# Altium schematic sheet Power.SchDoc
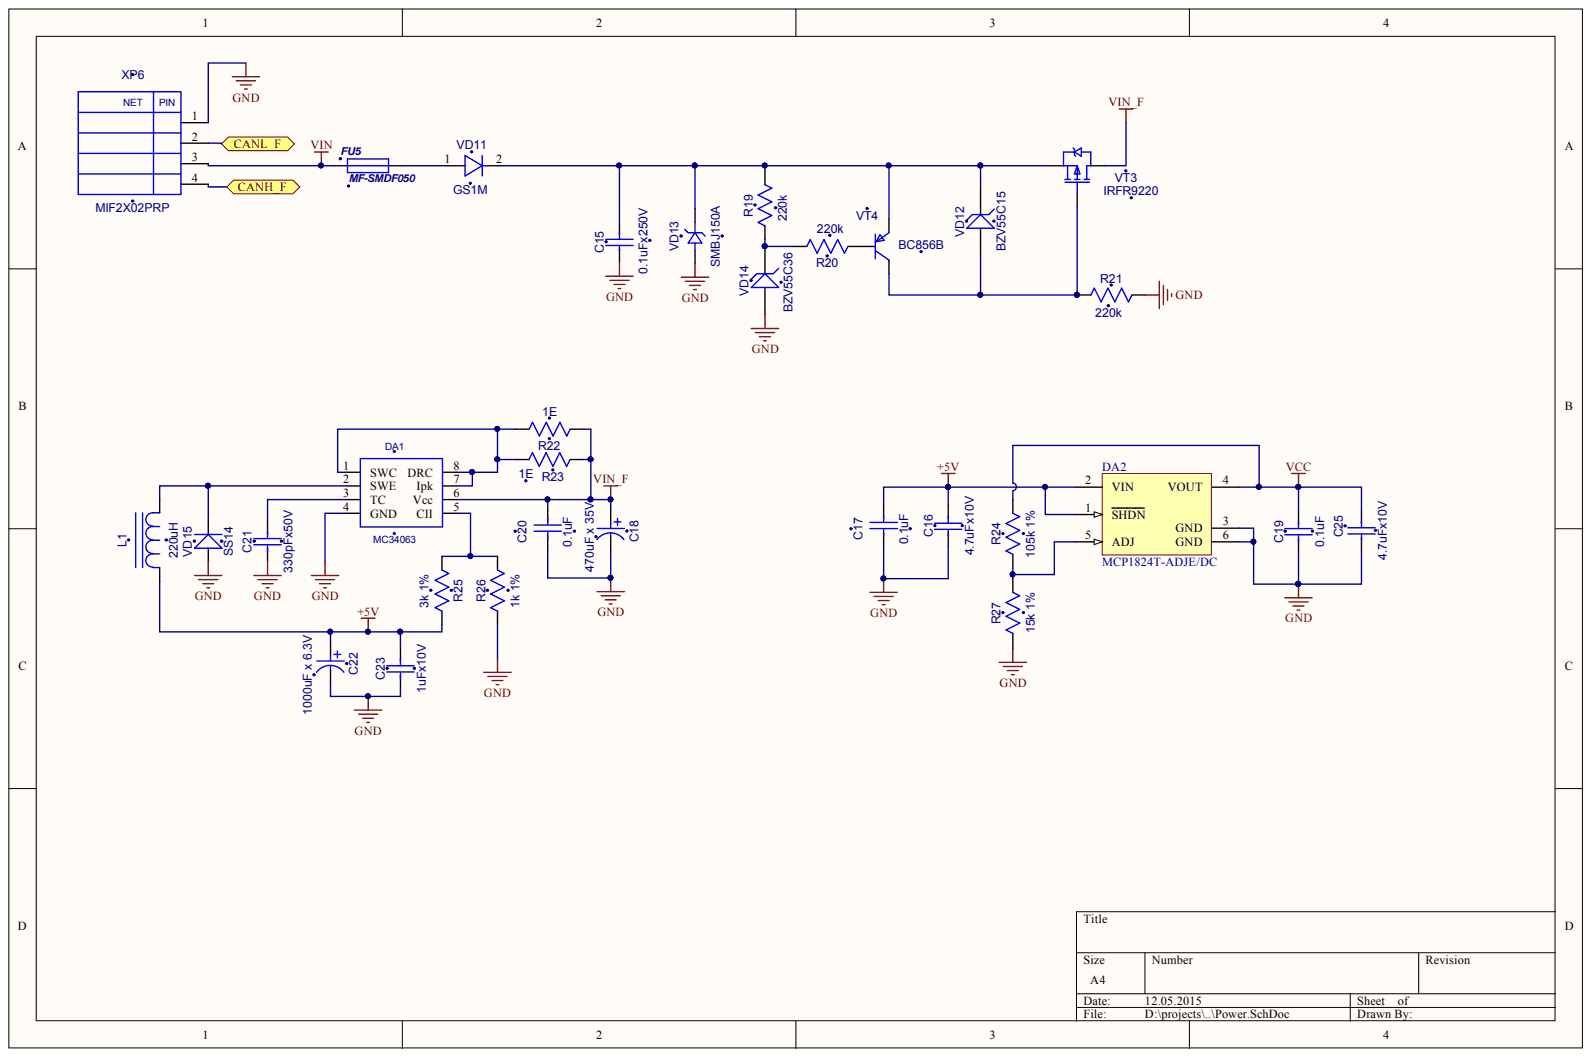
[diagram p0: Power.SchDoc]

## Parts
C15  0.1uFx250V  [CC1206-MIDI]
C16  4.7uFx10V  [CC0805-MIDI-2M1]
C17  0.1uF  [CC0603-3M]
C18  470uF x 35V  [CC16X10_CAP0.5(1)(Primary)]
C19  0.1uF  [CC0603-3M]
C20  0.1uF  [CC0603-3M]
C21  330pFx50V  [CC0603-3M]
C22  1000uF x 6.3V  [CC8X3.5]
C23  1uFx10V  [CC0603-3M]
C25  4.7uFx10V  [CC0805-MIDI-2M1]
DA1  MC34063  [SO-8]
DA2  MCP1824T-ADJE/DC  [SOT223-6]
FU5  MF-SMDF050  [MF-SMDF]
L1  220uH  [SDR0805]
R19  220k  [RC1206-2M]
R20  220k  [RC1206-2M]
R21  220k  [RC1206-2M]
R22  1E  [RC0805_IPC_NOM]
R23  1E  [RC0805_IPC_NOM]
R24  105k 1%  [RC0603-3M]
R25  3k 1%  [RC0603-3M]
R26  1k 1%  [RC0603-3M]
R27  15k 1%  [RC0603-3M]
VD11  GS1M  [SMA]
VD12  BZV55C15  [SOD-80]
VD13  SMBJ150A  [SMB]
VD14  BZV55C36  [SOD-80]
VD15  SS14  [SMA]
VT3  IRFR9220  [D-PAK(TO-252AA)]
VT4  BC856B  [SOT-23(123)]
XP6  MIF2X02PRP  [MIF2X02PRP]

## Ports
CANH_F, CANL_F

## Nets
+5V: C16.2 C17.1 C22.1 C23.2 DA2.1(SHDN) DA2.2(VIN) L1.1 R25.2
GND: C15.1 C16.1 C17.2 C18.1 C19.1 C20.2 C21.1 C22.2 C23.1 C25.1 DA1.4(GND) DA2.3(GND) DA2.6(GND) R21.2 R26.1 R27.1 VD13.1 VD14.1 VD15.1 XP6.1
VCC: C19.2 C25.2 DA2.4(VOUT) R24.2
VIN: FU5.1 XP6.3
VIN_F: C18.2 C20.1 DA1.6(Vcc) R22.1 R23.1 VT3.3
CANH_F: XP6.4 ; port CANH_F
CANL_F: XP6.2 ; port CANL_F
NetC15_2: C15.2 R19.2 VD11.2 VD12.2 VD13.2 VT3.2 VT4.2
NetC21_2: C21.2 DA1.3(TC)
NetDA1_1: DA1.1(SWC) DA1.7(Ipk) DA1.8(DRC) R22.2 R23.2
NetDA1_2: DA1.2(SWE) L1.2 VD15.2
NetDA1_5: DA1.5(CII) R25.1 R26.2
NetDA2_5: DA2.5(ADJ) R24.1 R27.2
NetFU5_2: FU5.2 VD11.1
NetR19_1: R19.1 R20.2 VD14.2
NetR20_1: R20.1 VT4.1
NetR21_1: R21.1 VD12.1 VT3.1 VT4.3

## Library data of the parts
C15 = CC1206: Component Kind: Standard; Description: CC1206; Value: 0.1uFx250V; Library Name: gprs_modem.SchLib
C16 C25 = CC0805: Component Kind: Standard; Description: CC0805; Value: 4.7uFx10V; Library Name: gprs_modem.SchLib
C17 C19-C21 C23 = CC0603: Component Kind: Standard; Description: CC0603; Library Name: gprs_modem.SchLib
  C17 C19 C20: Value: 0.1uF
  C21: Value: 330pFx50V
  C23: Value: 1uFx10V
C18 = CAP0.5(1): Component Kind: Standard; Description: CAP0.5(1); Value: 470uF x 35V; Library Name: gprs_modem.SchLib
C22 = CAP0.35: Component Kind: Standard; Description: CAP0.35; Value: 1000uF x 6.3V; Library Name: gprs_modem.SchLib
DA2 = MCP1824T-ADJE/DC: 300 mA, Low Voltage, Low Quiescent Current LDO Regulator, 5-Pin SOT-223, Extended Temperature, Tape and Reel; Component Kind: Standard; ComponentLink1Description: Manufacturer Link; ComponentLink1URL: http://www.microchip.com; ComponentLink2Description: Datasheet; ComponentLink2URL: http://ww1.microchip.com/downloads/en/DeviceDoc/22070a.pdf; ComponentLink3Description: Packaging Specification; ComponentLink3URL: http://ww1.microchip.com/downloads/en/PackagingSpec/00049AW.pdf; DatasheetVersion: revA, Aug-2007; PackageDescription: 5-Lead Plastic Small Outline Transistor (DC) [SOT-223]; PackageReference: SOT-223-DC5; PackageVersion: revAW, Oct-2008; Published: 29-Jan-2009; Library Name: gprs_modem.SchLib; Publisher: Altium Limited
FU5 = MF-SMDF: Component Kind: Standard; Description: MF-SMDF; Value: MF-SMDF050; Library Name: gprs_modem.SchLib
L1 = SDR0805: Component Kind: Standard; Description: SDR0805; Value: 220uH; Library Name: gprs_modem.SchLib
R19-R21 = RC1206: Component Kind: Standard; Description: RC1206; Value: 220k; Library Name: gprs_modem.SchLib
R22 R23 = RC0805: Component Kind: Standard; Description: RC0805; Value: 1E; Library Name: gprs_modem.SchLib
R24-R27 = RC0603: Component Kind: Standard; Description: RC0603; Library Name: gprs_modem.SchLib
  R24: Value: 105k 1%
  R25: Value: 3k 1%
  R26: Value: 1k 1%
  R27: Value: 15k 1%
VD11 = GS1M: Value: {Value}; Component Kind: Standard; Description: GS1M; Library Name: Schetchik.SchLib
VD12 VD14 = BZV55C12: Zener Voltage Regulator Diode; ComponentField6: Pin 2 is not connected and not drawn.; ComponentField7: Published 29-Sep-2000; OriginalName: BZX84C10LT1; Component Kind: Standard; Simulation: BZX84C10LT1; Library Name: gprs_modem.SchLib
  VD12: Value: BZV55C15
  VD14: Value: BZV55C36
VD13 = SMBJ: Component Kind: Standard; Description: SMBJ; Value: SMBJ150A; Library Name: gprs_modem.SchLib
VD15 = SS14: Schottky Rectifier; Component Kind: Standard; Value: SS14; Library Name: gprs_modem.SchLib
VT3 = IRFR9220: HEXFET Power MOSFET; ComponentField7: Published 8-Jun-2000; OriginalName: IRLML5103; Component Kind: Standard; Value: IRFR9220; Library Name: gprs_modem.SchLib
VT4 = BC856B: ComponentField7: Published 29-Sep-2000; OriginalName: BC856BLT; Component Kind: Standard; Description: BC856B; Simulation: BC846BLT1; Value: BC856B; Library Name: Schetchik.SchLib
DA1 = MC34063: Component Kind: Standard; Description: MC34063; Library Name: Schetchik.SchLib
XP6 = MIF2X02PRP: Component Kind: Standard; Description: MIF2X02PRP; Library Name: Schetchik.SchLib
